# Altium schematic sheet FOC.SchDoc
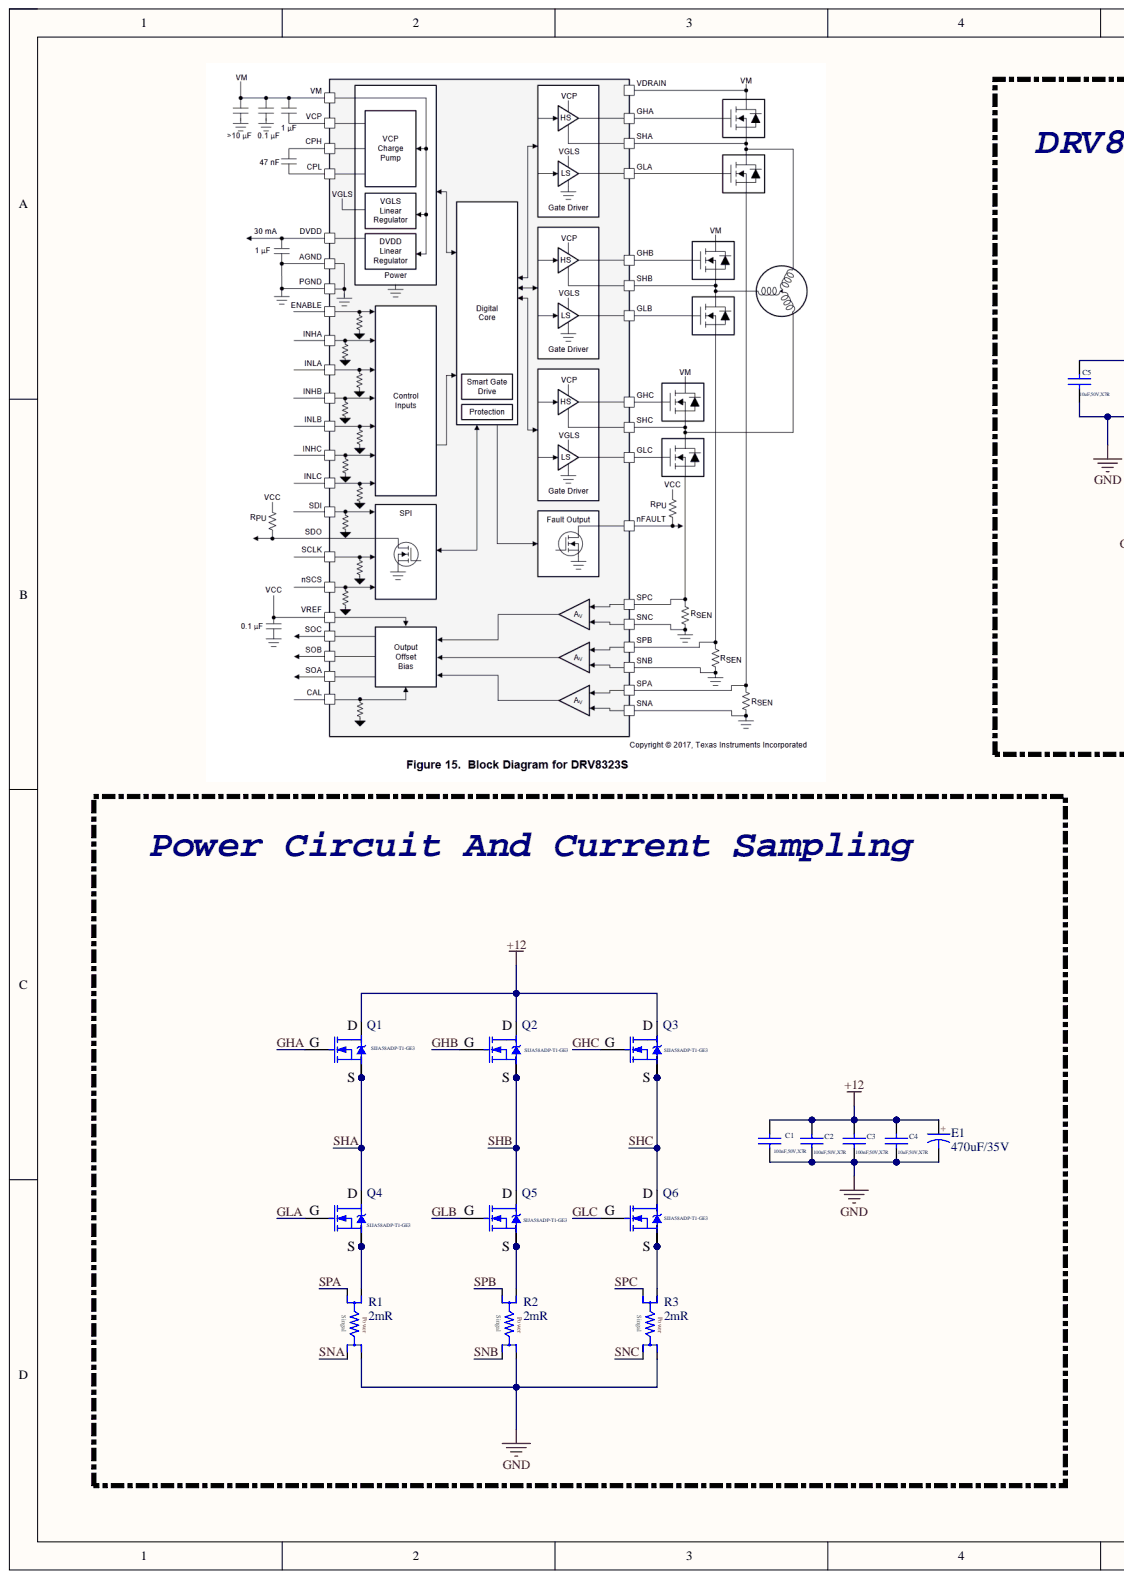
[diagram p0: FOC.SchDoc, left]
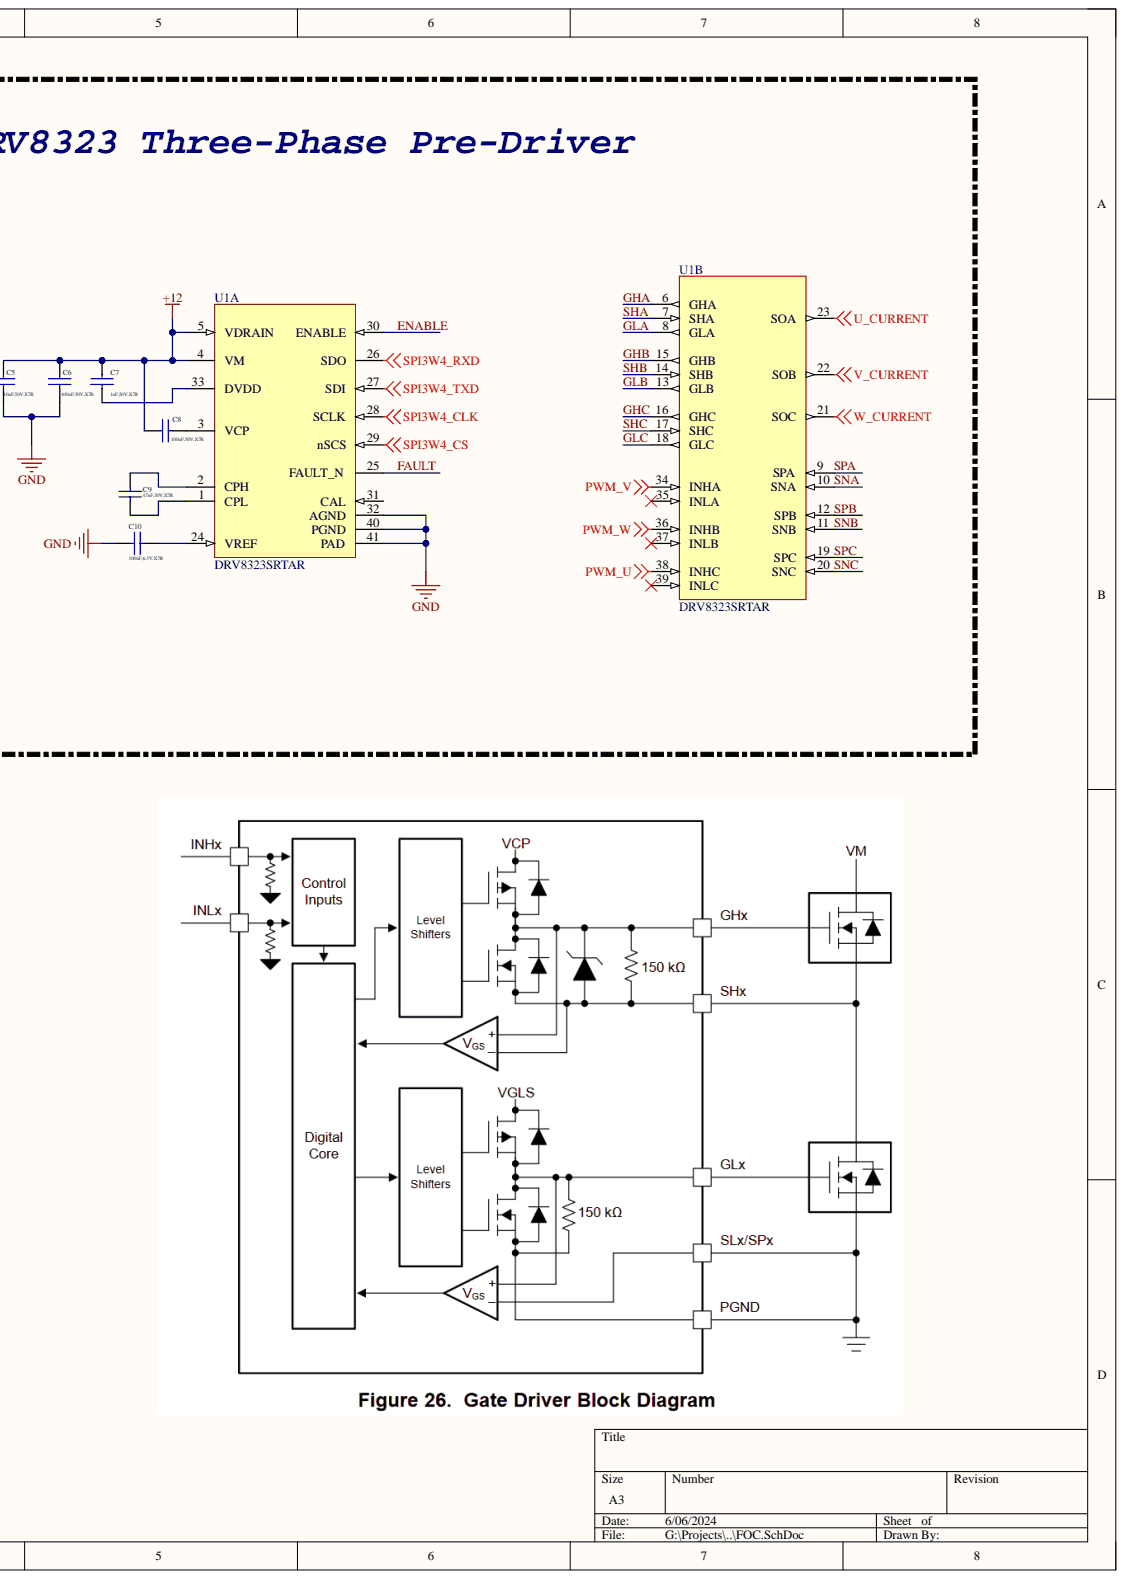
[diagram p1: FOC.SchDoc, right]

## Parts
C1  100nF,50V,X7R  [C0603_L]
C2  100nF,50V,X7R  [C0603_L]
C3  100nF,50V,X7R  [C0603_L]
C4  10uF,50V,X7R  [C0603_L]
C5  10uF,50V,X7R  [C0402_L]
C6  100nF,50V,X7R  [C0402_L]
C7  1uF,50V,X7R  [C0402_L]
C8  100nF,50V,X7R  [C0402_L]
C9  47nF,50V,X7R  [C0402_L]
C10  100nF,6.3V,X7R  [C0402_L]
E1  470uF/35V  [CE E(8*6.2)]
Q1  SIJA58ADP-T1-GE3  [POWERPAK SO-8L_VIS]
Q2  SIJA58ADP-T1-GE3  [POWERPAK SO-8L_VIS]
Q3  SIJA58ADP-T1-GE3  [POWERPAK SO-8L_VIS]
Q4  SIJA58ADP-T1-GE3  [POWERPAK SO-8L_VIS]
Q5  SIJA58ADP-T1-GE3  [POWERPAK SO-8L_VIS]
Q6  SIJA58ADP-T1-GE3  [POWERPAK SO-8L_VIS]
R1  2mR  [WSKW0612_VIS]
R2  2mR  [WSKW0612_VIS]
R3  2mR  [WSKW0612_VIS]
U1  DRV8323SRTAR  [DRV8323SRTAR-Footprint-1]  (parts A,B)

## Nets
+12: C1.1 C2.1 C3.1 C4.1 C5.2 C6.2 C7.1 C8.1 E1.1 Q1.5 Q2.5 Q3.5 U1.4(VM) U1.5(VDRAIN)
GND: C1.2 C2.2 C3.2 C4.2 C5.1 C6.1 C10.1 E1.2 R1.4 R2.4 R3.4 U1.32(AGND) U1.40(PGND) U1.41(PAD)
PWM_U: U1.38(INHC)
PWM_V: U1.34(INHA)
PWM_W: U1.36(INHB)
SPI3W4_CLK: U1.28(SCLK)
SPI3W4_CS: U1.29(nSCS)
SPI3W4_RXD: U1.26(SDO)
SPI3W4_TXD: U1.27(SDI)
U_CURRENT: U1.23(SOA)
V_CURRENT: U1.22(SOB)
W_CURRENT: U1.21(SOC)
ENABLE: U1.30(ENABLE)
FAULT: U1.25(FAULT_N)
GHA: Q1.4 U1.6(GHA)
GHB: Q2.4 U1.15(GHB)
GHC: Q3.4 U1.16(GHC)
GLA: Q4.4 U1.8(GLA)
GLB: Q5.4 U1.13(GLB)
GLC: Q6.4 U1.18(GLC)
SHA: Q1.1 Q1.2 Q1.3 Q4.5 U1.7(SHA)
SHB: Q2.1 Q2.2 Q2.3 Q5.5 U1.14(SHB)
SHC: Q3.1 Q3.2 Q3.3 Q6.5 U1.17(SHC)
SNA: R1.3 U1.10(SNA)
SNB: R2.3 U1.11(SNB)
SNC: R3.3 U1.20(SNC)
SPA: R1.2 U1.9(SPA)
SPB: R2.2 U1.12(SPB)
SPC: R3.2(Singal) U1.19(SPC)
NetC7_2: C7.2 U1.33(DVDD)
NetC8_2: C8.2 U1.3(VCP)
NetC9_1: C9.1 U1.2(CPH)
NetC9_2: C9.2 U1.1(CPL)
NetC10_2: C10.2 U1.24(VREF)
NetQ4_1: Q4.1 Q4.2 Q4.3 R1.1
NetQ5_1: Q5.1 Q5.2 Q5.3 R2.1
NetQ6_1: Q6.1 Q6.2 Q6.3 R3.1

Not connected: U1 31(CAL) 35(INLA) 37(INLB) 39(INLC)

## Library data of the parts
C1-C10 = C: 贴片电容/MLCC电容; BOM名称: 无极性贴片电容; 当前库版本V2023.5.31 @淘宝卖家: 最新版本发布https://item.taobao.com/item.htm?id=566775463719; Component Kind: Standard; Library Name: 0.1 - 电阻-电容-电感-保险丝-晶振-晶体-磁珠-电位器-法拉电容-馈通电容.SchLib
E1 = CE_SMD: 贴片电解电容; 当前库版本V2023.5.31 @淘宝卖家: 最新版本发布https://item.taobao.com/item.htm?id=566775463719; BOM名称: 贴片电解电容; Component Kind: Standard; Library Name: 0.1 - 电阻-电容-电感-保险丝-晶振-晶体-磁珠-电位器-法拉电容-馈通电容.SchLib
Q1-Q6 = CMP-00055-2: Power Field-Effect Transistor; Component Kind: Standard; Library Name: 海南大学MECHA战队
R1-R3 = CMP-00060-2: SMD Chip Resistor, 2 MOhm, ± 1%, 1 W, 0612 [1632 Metric], Metal Strip, High Power; Component Kind: Standard; Library Name: 海南大学MECHA战队
U1 = CMP-00062-1: 65-V max 3-phase smart gate driver with current shunt amplifiers 40-WQFN -40 to 125; Component Kind: Standard; Library Name: 海南大学MECHA战队
